# Altium schematic sheet microcontroller.SchDoc(microcontroller)
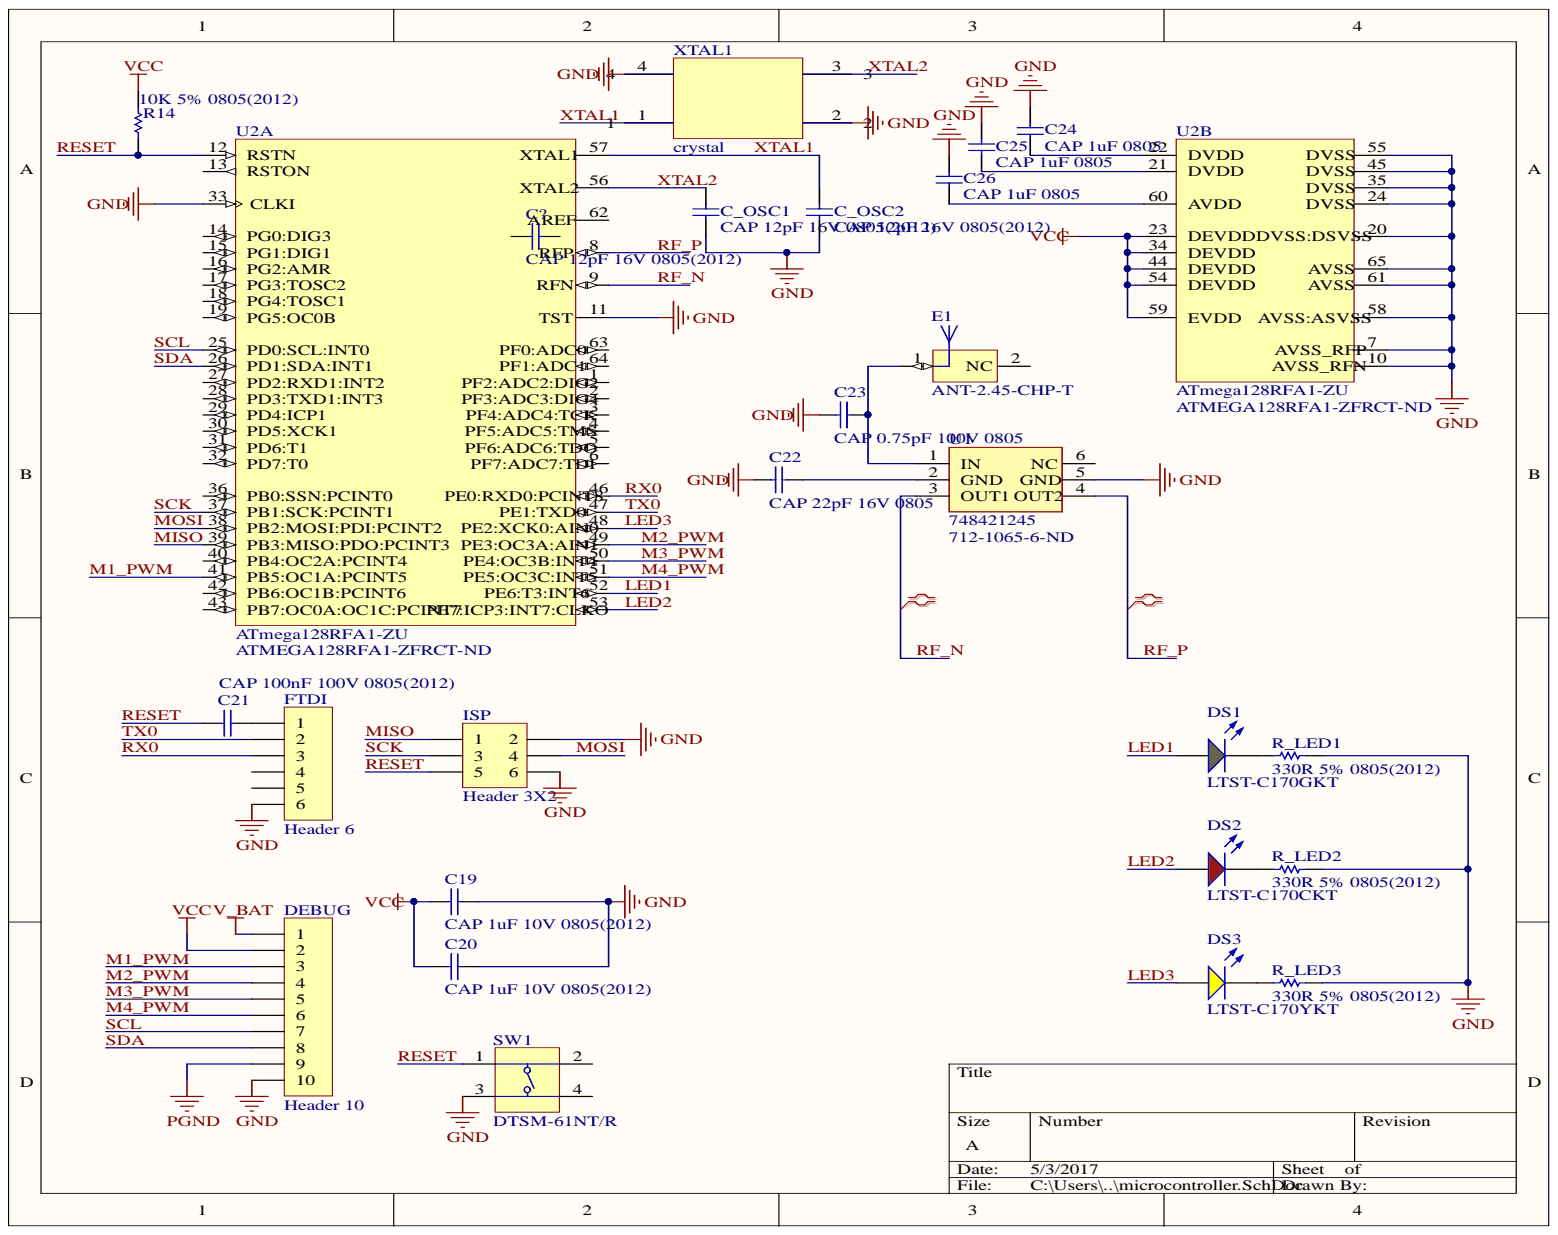
[diagram p0: microcontroller.SchDoc]

## Parts
C19  CAP 1uF 10V 0805(2012)  value 1uF  [CAPC0805(2012)145_N]
C20  CAP 1uF 10V 0805(2012)  value 1uF  [CAPC0805(2012)145_N]
C21  CAP 100nF 100V 0805(2012)  value 100nF  [CAPC0805(2012)145_N]
C22  CAP 22pF 16V 0805  value 22pF  [CAPC0805(2012)100_L]
C23  CAP 0.75pF 100V 0805  value 0.75pF  [CAPC0805(2012)100_L]
C24  CAP 1uF 0805  value 1uF  [CAPC0805(2012)145_N]
C25  CAP 1uF 0805  value 1uF  [CAPC0805(2012)145_N]
C26  CAP 1uF 0805  value 1uF  [CAPC0805(2012)145_N]
C?  CAP 12pF 16V 0805(2012)  value 12pF  [CAPC0805(2012)100_L]
C_OSC1  CAP 12pF 16V 0805(2012)  value 12pF  [CAPC0805(2012)100_L]
C_OSC2  CAP 12pF 16V 0805(2012)  value 12pF  [CAPC0805(2012)100_L]
DEBUG  Header 10  [HDR1X10]
DS1  LTST-C170GKT  [LTST-C170GKT_V]
DS2  LTST-C170CKT  [LTST-C170CKT_V]
DS3  LTST-C170YKT  [LTST-C170YKT_V]
E1  ANT-2.45-CHP-T  [ANT-2.4-CHP]
FTDI  Header 6  [HDR1X6]
ISP  Header 3X2  [HDR2X3]
R14  10K 5% 0805(2012)  [RESC0805(2012)_L]
R_LED1  330R 5% 0805(2012)  [RESC0805(2012)_L]
R_LED2  330R 5% 0805(2012)  [RESC0805(2012)_L]
R_LED3  330R 5% 0805(2012)  [RESC0805(2012)_L]
SW1  DTSM-61NT/R  [DIPT-DTSM-6_V]
U1  748421245  Supplier Part Number 1: 712-1065-6-ND  Size: 0805  [WE-BAL_0805]
U2  ATmega128RFA1-ZU  Supplier Part Number 1: ATMEGA128RFA1-ZFRCT-ND  [64PI_N]  (parts A,B)
XTAL1  crystal  [crys]

## Nets
GND: C19.1 C20.2 C22.1 C23.2 C24.2 C25.2 C26.2 C_OSC1.1 C_OSC2.1 DEBUG.10 FTDI.6 ISP.2 ISP.6 R_LED1.2 R_LED2.2 R_LED3.2 SW1.3 U1.5(GND) U2.7 U2.10 U2.11(TST) U2.20 U2.24(DVSS) U2.33(CLKI) U2.35(DVSS) U2.45(DVSS) U2.55(DVSS) U2.58 U2.61(AVSS) U2.65(AVSS) XTAL1.2 XTAL1.4
PGND: DEBUG.9
V_BAT: DEBUG.1
VCC: C19.2 C20.1 DEBUG.2 R14.2 U2.23 U2.34(DEVDD) U2.44(DEVDD) U2.54(DEVDD) U2.59(EVDD)
LED1: DS1.1 U2.52
LED2: DS2.1 U2.53
LED3: DS3.1 U2.48
M1_PWM: DEBUG.3 U2.41(PB5:OC1A:PCINT5)
M2_PWM: DEBUG.4 U2.49
M3_PWM: DEBUG.5 U2.50
M4_PWM: DEBUG.6 U2.51
MISO: ISP.1 U2.39(PB3:MISO:PDO:PCINT3)
MOSI: ISP.4 U2.38(PB2:MOSI:PDI:PCINT2)
RESET: C21.1 ISP.5 R14.1 SW1.1 U2.12(RSTN)
RF_N: U1.3(OUT1) U2.9(RFN)
RF_P: U1.4(OUT2) U2.8(RFP)
RX0: FTDI.3 U2.46
SCK: ISP.3 U2.37(PB1:SCK:PCINT1)
SCL: DEBUG.7 U2.25(PD0:SCL:INT0)
SDA: DEBUG.8 U2.26(PD1:SDA:INT1)
TX0: FTDI.2 U2.47
XTAL1: C_OSC2.2 U2.57 XTAL1.1
XTAL2: C_OSC1.2 U2.56 XTAL1.3
NetC21_2: C21.2 FTDI.1
NetC22_2: C22.2 U1.2(GND)
NetC23_1: C23.1 E1.1 U1.1(IN)
NetC24_1: C24.1 U2.22(DVDD)
NetC25_1: C25.1 U2.21(DVDD)
NetC26_1: C26.1 U2.60(AVDD)
NetDS1_2: DS1.2 R_LED1.1
NetDS2_2: DS2.2 R_LED2.1
NetDS3_2: DS3.2 R_LED3.1

Not connected: C? 1 2; E1 2; FTDI 4 5; SW1 2 4; U1 6(NC); U2 1 2 3 4 5 6 13(RSTON) 14(PG0:DIG3) 15(PG1:DIG1) 16(PG2:AMR) 17(PG3:TOSC2) 18(PG4:TOSC1) 19(PG5:OC0B) 27(PD2:RXD1:INT2) 28(PD3:TXD1:INT3) 29(PD4:ICP1) 30(PD5:XCK1) 31(PD6:T1) 32(PD7:T0) 36(PB0:SSN:PCINT0) 40(PB4:OC2A:PCINT4) 42(PB6:OC1B:PCINT6) 43(PB7:OC0A:OC1C:PCINT7) 62(AREF) 63 64

## Library data of the parts
C19 C20 C24-C26 = CMP-1036-04738-1: CAP 1uF 10V ±10% 0805 (2012 Metric) Thickness 1.45mm SMD; Tolerance: Â±10%; Case-Metric: 2012; Case-EIA: 0805; Technology: SMT; Rated Voltage: 10 V; Max Thickness: 1.45 mm; Library Name: Altium Content Vault; Component Kind: Standard
  C25: Value: 1uF
C21 = CMP-1036-04431-1: CAP 100nF 100V ±20% 0805 (2012 Metric) Thickness 1.45mm SMD; Tolerance: Â±20%; Case-Metric: 2012; Case-EIA: 0805; Value: 100nF; Technology: SMT; Rated Voltage: 100 V; Max Thickness: 1.45 mm; Library Name: Altium Content Vault; Component Kind: Standard
C22 = CMP-1036-01214-1: CAP 22pF 16V ±0.25pF 0805 (2012 Metric) Thickness 1mm SMD; Tolerance: Â±0.25pF; Case-Metric: 2012; Case-EIA: 0805; Technology: SMT; Rated Voltage: 16 V; Max Thickness: 1 mm; Library Name: Altium Content Vault; Component Kind: Standard
C23 = CMP-1036-00042-1: CAP 0.75pF 100V ±0.5pF 0805 (2012 Metric) Thickness 1mm SMD; Tolerance: Â±0.5pF; Case-Metric: 2012; Case-EIA: 0805; Value: 0.75pF; Technology: SMT; Rated Voltage: 100 V; Max Thickness: 1 mm; Library Name: Altium Content Vault; Component Kind: Standard
C? C_OSC1 C_OSC2 = CMP-1036-00926-1: CAP 12pF 16V ±0.5pF 0805 (2012 Metric) Thickness 1mm SMD; Tolerance: Â±0.5pF; Case-Metric: 2012; Case-EIA: 0805; Technology: SMT; Rated Voltage: 16 V; Max Thickness: 1 mm; Library Name: Altium Content Vault; Component Kind: Standard
  C?: Value: 12pF
DEBUG = Header 10: Header, 10-Pin; Signal Integrity: Connector; Library Name: Miscellaneous Connectors.IntLib; LatestRevisionNote: Re-released for DXP Platform.; LatestRevisionDate: 17-Jul-2002; Component Kind: Standard; Publisher: Altium Limited
DS1 = CMP-1674-00002-5: LED Uni-Color Green, 100 mW, 120 mA, -55 to 85 degC, 2-Pin SMD, RoHS, Tape and Reel; Manufacturer: Lite-On; RoHSCompliant: true; Pins: 2; Packaging: Tape and Reel; PackageReference: C170GKT; Package Quantity: 1; PackageDescription: 2-Pin Surface Mount Device, Body 2 x 1.25 mm; Mounting Technology: Surface Mount; Mount: Surface Mount; Min Operating Temperature: -55 degC; Max Operating Temperature: 85 degC; Library Name: Altium Content Vault; Length: 2 mm; Height: 1.1 mm; DatasheetVersion: 03/2003; ComponentLink2URL: http://media.digikey.com/pdf/Data%20Sheets/Lite-On%20PDFs/LTST-C170GKT.pdf; ComponentLink2Description: Datasheet; ComponentLink1URL: http://www.us.liteon.com/; ComponentLink1Description: Manufacturer URL; Component Kind: Standard; Colour: Green
DS2 = CMP-1674-00001-5: LED Uni-Color Red, 100 mW, 200 mA, -55 to 85 degC, 2-Pin SMD, RoHS, Tape and Reel; Manufacturer: Lite-On; RoHSCompliant: true; Pins: 2; Packaging: Tape and Reel; PackageReference: C170CKT; Package Quantity: 3000; PackageDescription: 2-Pin Surface Mount Device, Body 2 x 1.25 mm; Mounting Technology: Surface Mount; Mount: Surface Mount; Min Operating Temperature: -55 degC; Max Operating Temperature: 85 degC; Library Name: Altium Content Vault; Length: 2 mm; Height: 1.1 mm; DatasheetVersion: 03/2003; ComponentLink2URL: http://media.digikey.com/pdf/Data%20Sheets/Lite-On%20PDFs/LTST-C170CKT.pdf; ComponentLink2Description: Datasheet; ComponentLink1URL: http://www.us.liteon.com/; ComponentLink1Description: Manufacturer URL; Component Kind: Standard; Colour: Red
DS3 = CMP-1674-00003-5: LED Uni-Color Yellow, 60 mW, 80 mA, -55 to 85 degC, 2-Pin SMD, RoHS, Tape and Reel; Manufacturer: Lite-On; RoHSCompliant: true; Pins: 2; Packaging: Tape and Reel; PackageReference: C170YKT; Package Quantity: 3000; PackageDescription: 2-Pin Surface Mount Device, Body 2 x 1.25 mm; Mounting Technology: Surface Mount; Mount: Surface Mount; Min Operating Temperature: -55 degC; Max Operating Temperature: 85 degC; Library Name: Altium Content Vault; Length: 2 mm; Height: 1.1 mm; DatasheetVersion: 03/2000; ComponentLink2URL: http://media.digikey.com/pdf/Data Sheets/Lite-On PDFs/LTST-C170YKT.pdf; ComponentLink2Description: Datasheet; ComponentLink1URL: http://www.us.liteon.com/; ComponentLink1Description: Manufacturer URL; Component Kind: Standard; Colour: Yellow
E1 = CMP-0172-00025-1: 1/4-wave Monopole Ultra-Compact Chip Antenna, CHP Series, 2.4GHz, Tape and Reel; PCB_Layout: Complies with manufacturer's recommendation.; Note2: Pin 1 is feed terminal, Pin 2 is for physical support only.; Note1: No ground planes or traces under the antenna; PackageVersion: Jan-2009; PackageReference: ANT-2.4-CHP; PackageDescription: SMD Ceramic Antenna, CHP Series, 2.45MHz, 2-Leads, 6.5 x 2.2 x 1.0 mm Body; Library Name: Altium Content Vault; DatasheetVersion: Jan-2009; Component Kind: Standard
FTDI = Header 6: Header, 6-Pin; Signal Integrity: Connector; Library Name: Miscellaneous Connectors.IntLib; LatestRevisionNote: Re-released for DXP Platform.; LatestRevisionDate: 17-Jul-2002; Component Kind: Standard; Publisher: Altium Limited
ISP = Header 3X2: Header, 3-Pin, Dual row; Signal Integrity: Connector; Library Name: Miscellaneous Connectors.IntLib; LatestRevisionNote: Re-released for DXP Platform.; LatestRevisionDate: 17-Jul-2002; Component Kind: Standard; Publisher: Altium Limited
R14 = CMP-1013-00098-1: 10K 0.125W 5% 0805 (2012 Metric)  SMD; Value: 10000.00 Ohm; Case-Metric: 2012; Case-EIA: 0805; Tolerance: 5 %; Technology: SMT; Power: 0.125 W; Library Name: Altium Content Vault; Component Kind: Standard
R_LED1-R_LED3 = CMP-1013-00062-1: 330R 0.125W 5% 0805 (2012 Metric)  SMD; Value: 330.00 Ohm; Case-Metric: 2012; Case-EIA: 0805; Tolerance: 5 %; Technology: SMT; Power: 0.125 W; Library Name: Altium Content Vault; Component Kind: Standard
SW1 = CMP-0306-00001-1: Switch, SPNO Push Button SMD; Manufacturer: Diptronics; Packing Type: Tape&Reel; Mechanical Life: 200,000 cycles; PartNumber: DTSM-61NT/R; PackageReference: DTSM-6; PackageDescription: Switch, Through-Hole, 4 Leads, Body 8.5x8.5mm, Row Spacing 9.0mm, Pitch 4.5mm; Mounting Technology: Through Hole; Library Name: Altium Content Vault; ComponentLink3URL: http://www.dip.com.tw/PDF/pro_tact/DTSM_G_-6_2_-V.pdf; ComponentLink3Description: Package Specification; ComponentLink2URL: http://www.dip.com.tw/Products_tact.htm; ComponentLink2Description: Datasheet; ComponentLink1URL: http://www.dip.com.tw/; ComponentLink1Description: Manufacturer URL; Component Kind: Standard
U1 = 748421245: WE-BAL Multilayer Chip Balun, size 0805; Frequence: 2400-2500MHz; BalancedImpedance: 100Ω; Supplier 1: Digikey; PublishedDate: Aug 2016; PartNumber: 748421245; Library Name: lab4.IntLib; ComponentLink2URL: http://katalog.we-online.de/pbs/datasheet/748421245.pdf; ComponentLink2Description: Datasheet; ComponentLink1URL: http://www.we-online.de; ComponentLink1Description: Manufacturer; Component Kind: Standard; Publisher: Wurth Elektronik
U2 = CMP-0107-00010-2: 8-bit AVR Microcontroller with Low Power 2.4GHz Transceiver for ZigBee and IEEE 802.15.4, 64-Pin VQFN (Sawn) (PI64), Industrial (-40°C to 85°C); UART: 1; Transmit Current Consumption (mA): 18.6; Timers: 6; SRAM (Kbytes): 16; Receiver Sensitivity (dBm): -100; Receive Current Consumption (mA): 16.6; Power Output (dBm): 3.5; Operating Voltage (Vcc): 1.8 to 3.6; Max I/O Pins: 38; Max Data Rate (Mb/s): 2; Max. Operating Frequency: 16 MHz; Link Budget (dBm): 103.5; Flash (Kbytes): 128 Kbytes; External PA Control: Yes; EEPROM (Bytes): 4096; Crypto Engine: AES; ADC Resolution (bits): 10; ADC channels: 8; TWI (I2C): 1; Supplier 1: Digikey; SPI: 1; RevisionNote: Updated to Oct-2011 datasheet, symbol revised, parameters added; PartId: 1; PackageVersion: revA, Feb-2008; PackageReference: 64PI; PackageDescription: 64-Pin Quad Flat No-Lead (QFN), 9 x 9 mm Body, 0.5 mm Pitch, 5.65 x 4.75 mm Exposed Pad; Library Name: lab4.IntLib; Frequency Band: 2.4 GHz; DatasheetVersion: rev C, Oct-2011; ComponentLink2URL: http://www.atmel.com/Images/doc8266.pdf; ComponentLink2Description: Datasheet; ComponentLink1URL: http://www.atmel.com/; ComponentLink1Description: Manufacturer URL; Component Kind: Standard; Antenna Diversity: Yes; Analog Comparators: 1
XTAL1 = crystal: Library Name: crystal_sym.SCHLIB; Component Kind: Standard
